# Altium schematic sheet MainSheet.SchDoc
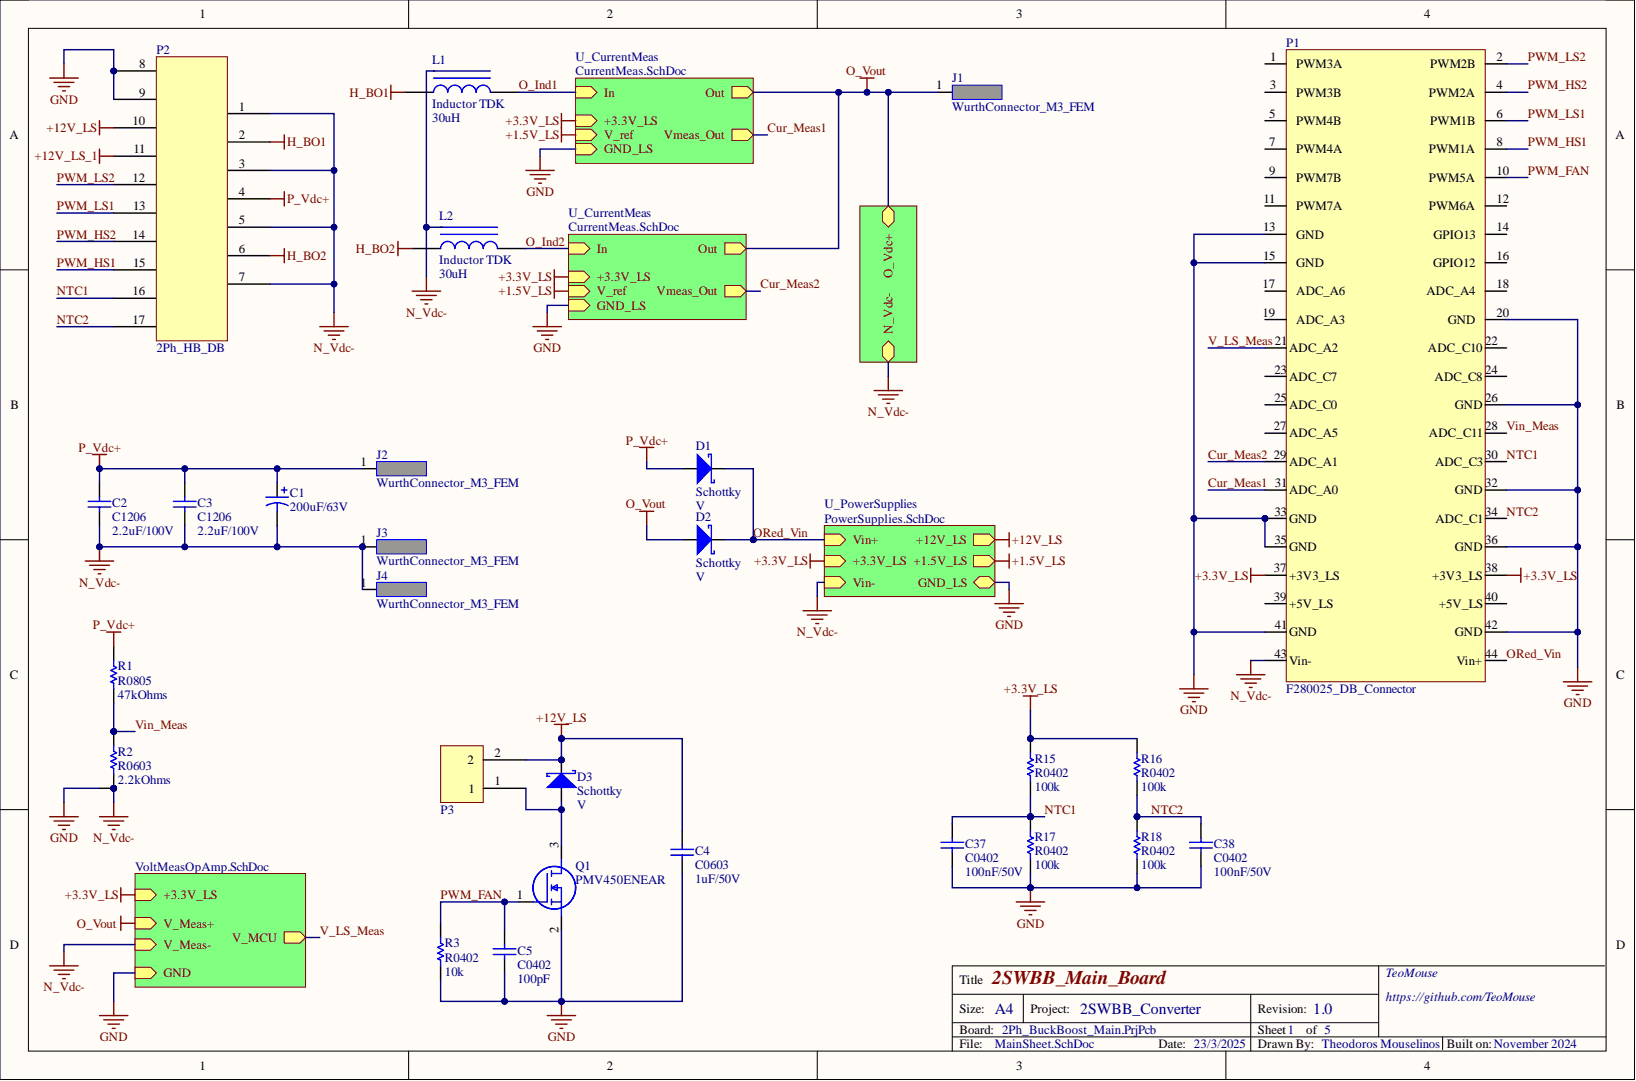
[diagram p0: MainSheet.SchDoc]

## Parts
C1  200uF/63V  [CapBoard]
C2  C1206  value 2.2uF/100V  [Capacitor 1206]
C3  C1206  value 2.2uF/100V  [Capacitor 1206]
C4  C0603  value 1uF/50V  [Capacitor 0603]
C5  C0402  value 100pF  [Capacitor 0402]
C37  C0402  value 100nF/50V  [Capacitor 0402]
C38  C0402  value 100nF/50V  [Capacitor 0402]
D1  Schottky  [SOD-123-2]
D2  Schottky  value V  [SOD-123-2]
D3  Schottky  value V  [SOD-123-2]
J1  WurthConnector_M3_FEM  [74650073R]
J2  WurthConnector_M3_FEM  [74650073R]
J3  WurthConnector_M3_FEM  [74650073R]
J4  WurthConnector_M3_FEM  [74650073R]
L1  Inductor TDK  value 30uH  [B82559A0303A024]
L2  Inductor TDK  value 30uH  [B82559A0303A024]
P1  F280025_DB_Connector  [Connector_2x22_2mmPitch]
P2  2Ph_HB_DB  [2Ph_HB_DB _Fem]
P3  1x2 2.54mm Straight Con  [1x2 2.54mm St_Con]  (value not drawn)
Q1  PMV450ENEAR  [SOT-23-3 (TO-236)]
R1  R0805  value 47kOhms  [Resistor 0805]
R2  R0603  value 2.2kOhms  [Resistor 0603]
R3  R0402  value 10k  [Resistor 0402]
R15  R0402  value 100k  [Resistor 0402]
R16  R0402  value 100k  [Resistor 0402]
R17  R0402  value 100k  [Resistor 0402]
R18  R0402  value 100k  [Resistor 0402]
T1  NetTie  [NetTie]  (value not drawn)

## Nets
+3.3V_LS: P1.37(+3V3_LS) P1.38(+3V3_LS) R15.2 R16.2
+12V_LS: C4.2 D3.1 P2.10 P3.2
+12V_LS_1: P2.11
GND: C4.1 C5.1 C37.1 C38.1 P1.13(GND) P1.15(GND) P1.20(GND) P1.26(GND) P1.32(GND) P1.33(GND) P1.35(GND) P1.36(GND) P1.41(GND) P1.42(GND) P2.8 P2.9 Q1.2 R3.1 R17.1 R18.1 T1.1
H_BO1: L1.2 P2.2
H_BO2: L2.2 P2.6
N_Vdc-: C1.2 C2.1 C3.1 J3.1 J4.1 L1.3 L2.3 P1.43(Vin-) P2.1 P2.3 P2.5 P2.7 R2.1 T1.2
O_Vout: D2.2 J1.1
P_Vdc+: C1.1 C2.2 C3.2 D1.2 J2.1 P2.4 R1.2
Cur_Meas1: P1.31(ADC_A0)
Cur_Meas2: P1.29(ADC_A1)
NTC1: C37.2 P1.30(ADC_C3) P2.16 R15.1 R17.2
NTC2: C38.2 P1.34(ADC_C1) P2.17 R16.1 R18.2
O_Ind1: L1.1
O_Ind2: L2.1
ORed_Vin: D1.1 D2.1 P1.44(Vin+)
PWM_FAN: C5.2 P1.10(PWM5A) Q1.1 R3.2
PWM_HS1: P1.8(PWM1A) P2.15
PWM_HS2: P1.4(PWM2A) P2.14
PWM_LS1: P1.6(PWM1B) P2.13
PWM_LS2: P1.2(PWM2B) P2.12
V_LS_Meas: P1.21(ADC_A2)
Vin_Meas: P1.28(ADC_C11) R1.1 R2.2
NetD3_2: D3.2 P3.1 Q1.3
NetP1_1: P1.1(PWM3A)
NetP1_3: P1.3(PWM3B)
NetP1_5: P1.5(PWM4B)
NetP1_7: P1.7(PWM4A)
NetP1_9: P1.9(PWM7B)
NetP1_11: P1.11(PWM7A)
NetP1_12: P1.12(PWM6A)
NetP1_14: P1.14(GPIO13)
NetP1_16: P1.16(GPIO12)
NetP1_17: P1.17(ADC_A6)
NetP1_18: P1.18(ADC_A4)
NetP1_19: P1.19(ADC_A3)
NetP1_22: P1.22(ADC_C10)
NetP1_23: P1.23(ADC_C7)
NetP1_24: P1.24(ADC_C8)
NetP1_25: P1.25(ADC_C0)
NetP1_27: P1.27(ADC_A5)
NetP1_39: P1.39(+5V_LS)
NetP1_40: P1.40(+5V_LS)
+1.5V_LS:

## Library data of the parts
C1 = CapsPCB: Component Kind: Standard; Library Name: CommonSchLib.SchLib
C2 C3 = C1206: Capacitor; Component Kind: Standard; LatestRevisionDate: 17-Jul-2002; LatestRevisionNote: Re-released for DXP Platform.; Library Name: CommonSchLib.SchLib; PackageDescription: Radial Cap, Thru-Hole; 2 Leads; 0.3 in Pin Spacing; PackageReference: RAD-0.3; Published: 8-Jun-2000; Supplier 1: Mouser; Supplier Part Number 1: 581-12061C225KAT2A; Publisher: Altium Limited
C4 = C0603: Capacitor; Component Kind: Standard; LatestRevisionDate: 17-Jul-2002; LatestRevisionNote: Re-released for DXP Platform.; Library Name: CommonSchLib.SchLib; PackageDescription: Radial Cap, Thru-Hole; 2 Leads; 0.3 in Pin Spacing; PackageReference: RAD-0.3; Published: 8-Jun-2000; Publisher: Altium Limited
C5 C37 C38 = C0402: Capacitor; Component Kind: Standard; LatestRevisionDate: 17-Jul-2002; LatestRevisionNote: Re-released for DXP Platform.; Library Name: CommonSchLib.SchLib; PackageDescription: Radial Cap, Thru-Hole; 2 Leads; 0.3 in Pin Spacing; PackageReference: RAD-0.3; Published: 8-Jun-2000; Publisher: Altium Limited
D1 = DiodeSchottky SMD: Schottky Diode; Note: Terminal 2 is the TAB and is electrically connected to the cathode; Value: V; Component Kind: Standard; ComponentLink1Description: Manufacturer Link; ComponentLink1URL: http://www.irf.com/; ComponentLink2Description: Datasheet; ComponentLink2URL: http://www.irf.com/product-info/datasheets/data/10tq.pdf; DatasheetVersion: PD-2.057B; LatestRevisionDate: 17-Jul-2002; LatestRevisionNote: Re-released for DXP Platform.; Library Name: CommonSchLib.SchLib; PackageDescription: TO, Thru-Hole, Vertical, Heatsink Mounted; 3 In-Line Leads (1 Absent); Pitch 2.54 mm; PackageReference: TO-220AC; PackageVersion: Feb-1998; Published: 8-Jun-2000; Supplier 1: Mouser; Supplier Part Number 1: 512-S110FA; Publisher: Altium Limited
D2 D3 = DiodeSchottky SMD: Schottky Diode; Note: Terminal 2 is the TAB and is electrically connected to the cathode; Component Kind: Standard; ComponentLink1Description: Manufacturer Link; ComponentLink1URL: http://www.irf.com/; ComponentLink2Description: Datasheet; ComponentLink2URL: http://www.irf.com/product-info/datasheets/data/10tq.pdf; DatasheetVersion: PD-2.057B; LatestRevisionDate: 17-Jul-2002; LatestRevisionNote: Re-released for DXP Platform.; Library Name: CommonSchLib.SchLib; PackageDescription: TO, Thru-Hole, Vertical, Heatsink Mounted; 3 In-Line Leads (1 Absent); Pitch 2.54 mm; PackageReference: TO-220AC; PackageVersion: Feb-1998; Published: 8-Jun-2000; Supplier 1: Mouser; Supplier Part Number 1: 512-S110FA; Publisher: Altium Limited
L1 L2 = Inductor TDK: Magnetic-Core Inductor; Component Kind: Standard; LatestRevisionDate: 17-Jul-2002; LatestRevisionNote: Re-released for DXP Platform.; Library Name: CommonSchLib.SchLib; PackageDescription: Axial Device, Thru-Hole; 2 Leads; 0.9 in Pin Spacing; PackageReference: AXIAL-0.9; Published: 24-Mar-1999; Supplier 1: Mouser; Supplier Part Number 1: 871-B82559A0303A024; Publisher: Altium Limited
Q1 = PMV450ENEAR: MOSFET (N-Channel); Manufacturer_Name: Infineon; Manufacturer_Part_Number: IPP023N08N5AKSA1; Component Kind: Standard; Datasheet Link: https://gr.mouser.com/datasheet/2/196/Infineon-IPP023N08N5-DS-v02_00-EN-1227118.pdf; Height: 4.57mm; Library Name: CommonSchLib.SchLib; Mouser2 Part Number: 726-IPP023N08N5AKSA1; Mouser2 Price/Stock: https://gr.mouser.com/ProductDetail/Infineon-Technologies/IPP023N08N5AKSA1?qs=%2Fha2pyFadujhW4Bob9P1cPVJ46syk6m574copaISrTUILyyC9SOcdJiVP5ogk9W1; Supplier 1: Mouser; Supplier Part Number 1: 771-PMV450ENEAR
R1 = R0805: Resistor; Component Kind: Standard; LatestRevisionDate: 17-Jul-2002; LatestRevisionNote: Re-released for DXP Platform.; Library Name: CommonSchLib.SchLib; PackageDescription: Axial Device, Thru-Hole; 2 Leads; 0.3 in Pin Spacing; PackageReference: AXIAL-0.3; Published: 8-Jun-2000; Publisher: Altium Limited
R2 = R0603: Resistor; Component Kind: Standard; LatestRevisionDate: 17-Jul-2002; LatestRevisionNote: Re-released for DXP Platform.; Library Name: CommonSchLib.SchLib; PackageDescription: Axial Device, Thru-Hole; 2 Leads; 0.3 in Pin Spacing; PackageReference: AXIAL-0.3; Published: 8-Jun-2000; Publisher: Altium Limited
R3 R15-R18 = R0402: Resistor; Component Kind: Standard; LatestRevisionDate: 17-Jul-2002; LatestRevisionNote: Re-released for DXP Platform.; Library Name: CommonSchLib.SchLib; PackageDescription: Axial Device, Thru-Hole; 2 Leads; 0.3 in Pin Spacing; PackageReference: AXIAL-0.3; Published: 8-Jun-2000; Publisher: Altium Limited
J1-J4 = WurthConnector_M3_FEM: Component Kind: Standard; Library Name: CommonSchLib.SchLib; Supplier 1: Mouser; Supplier Part Number 1: 710-74650073R
P1 = F280025_DB_Connector: Component Kind: Standard; Library Name: CommonSchLib.SchLib
P2 = 2Ph_HB_DB: Component Kind: Standard; Library Name: CommonSchLib.SchLib
P3 = 1x2 2.54mm Straight Con: Component Kind: Standard; Library Name: CommonSchLib.SchLib
T1 = NetTie: Component Kind: Net Tie; Library Name: CommonSchLib.SchLib
